# Altium schematic sheet hellen1-mega-mcu176.SchDoc(hellen1-mega-mcu176)
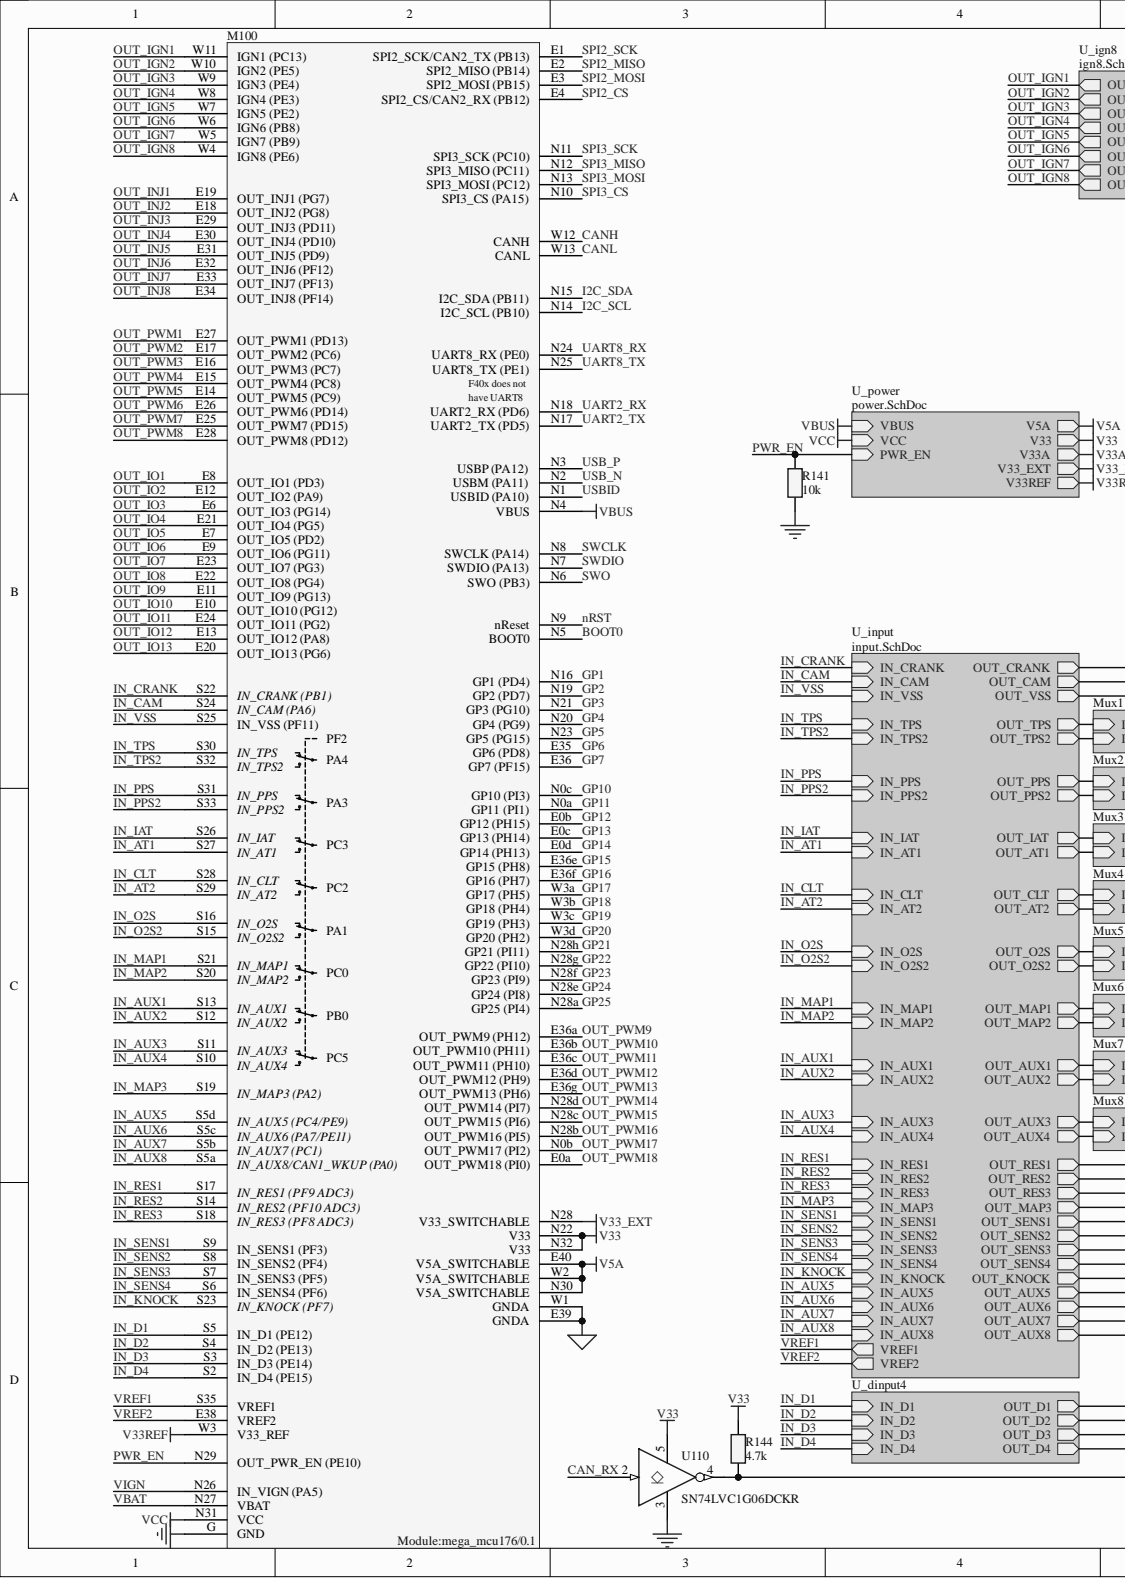
[diagram p0: hellen1-mega-mcu176.SchDoc, left]
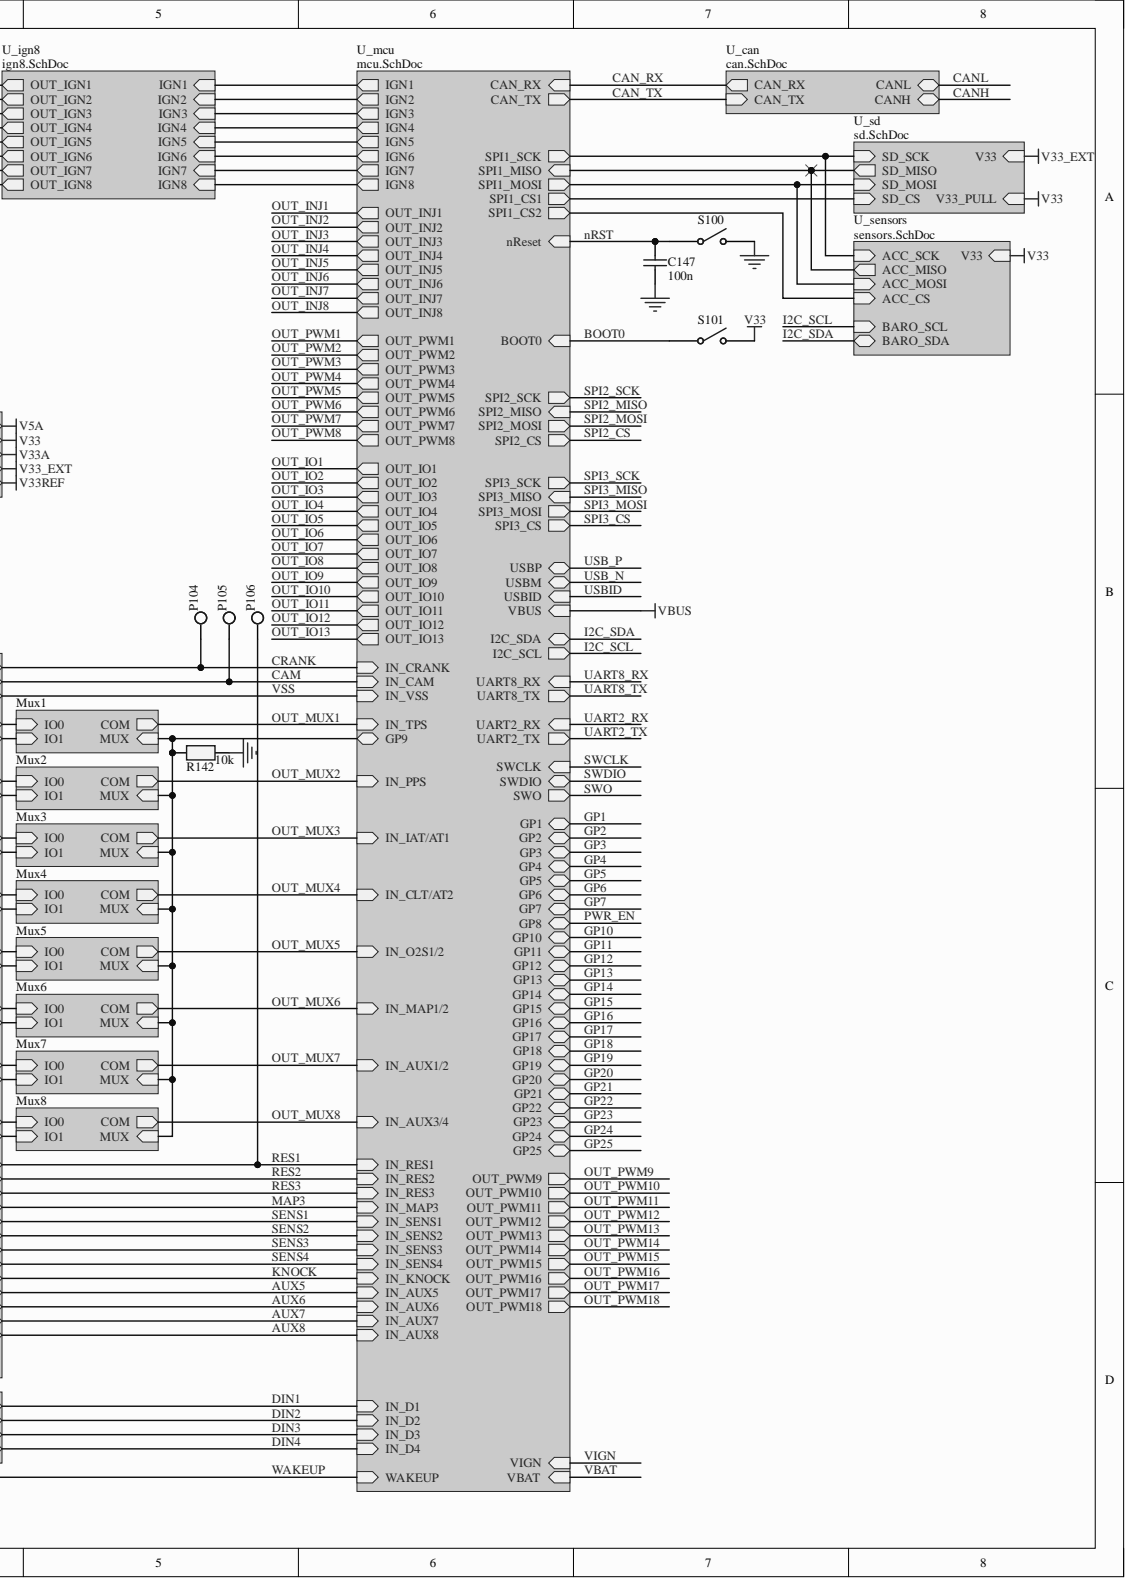
[diagram p1: hellen1-mega-mcu176.SchDoc, right]

## Parts
C147  100n  [C0402HD]
M100  Module:mega_mcu176/0.1  [MOD_Hellen_MEGA_MCU176_0.1]
P104  CRK  [HDR1X1]  (value not drawn)  (no BOM)
P105  CAM  [HDR1X1]  (value not drawn)  (no BOM)
P106  RES1  [HDR1X1]  (value not drawn)  (no BOM)
R141  10k  [R0402]
R142  10k  [R0402]
R144  4.7k  [R0402]
S100  BTN  [BUTTON-SKRK]  (value not drawn)
S101  BTN  [BUTTON-SKRK]  (value not drawn)
U110  SN74LVC1G06DCKR  [SOT-353]

## Nets
GND: C147.1 M100.G(GND) R141.1 R142.2 S100.2 U110.3
GNDA: M100.E39(GNDA) M100.W1(GNDA)
V5A: M100.E40(V5A_SWITCHABLE) M100.N30(V5A_SWITCHABLE) M100.W2(V5A_SWITCHABLE)
V33: M100.N22(V33) M100.N32(V33) R144.1 S101.2 U110.5
V33_EXT: M100.N28(V33_SWITCHABLE)
V33REF: M100.W3(V33_REF)
VBUS: M100.N4(VBUS)
VCC: M100.N31(VCC)
BOOT0: M100.N5(BOOT0) S101.1
CAM: P105.1
CAN_RX: U110.2
CANH: M100.W12(CANH)
CANL: M100.W13(CANL)
CRANK: P104.1
GP1: M100.N16(GP1_(PD4))
GP2: M100.N19(GP2_(PD7))
GP3: M100.N21(GP3_(PG10))
GP4: M100.N20(GP4_(PG9))
GP5: M100.N23(GP5_(PG15))
GP6: M100.E35(GP6_(PD8))
GP7: M100.E36(GP7_(PF15))
GP10: M100.N0c(GP10_(PI3))
GP11: M100.N0a(GP11_(PI1))
GP12: M100.E0b(GP12_(PH15))
GP13: M100.E0c(GP13_(PH14))
GP14: M100.E0d(GP14_(PH13))
GP15: M100.E36e(GP15_(PH8))
GP16: M100.E36f(GP16_(PH7))
GP17: M100.W3a(GP17_(PH5))
GP18: M100.W3b(GP18_(PH4))
GP19: M100.W3c(GP19_(PH3))
GP20: M100.W3d(GP20_(PH2))
GP21: M100.N28h(GP21_(PI11))
GP22: M100.N28g(GP22_(PI10))
GP23: M100.N28f(GP23_(PI9))
GP24: M100.N28e(GP24_(PI8))
GP25: M100.N28a(GP25_(PI4))
I2C_SCL: M100.N14(I2C_SCL_(PB10))
I2C_SDA: M100.N15(I2C_SDA_(PB11))
IN_AT1: M100.S27(IN_AT1)
IN_AT2: M100.S29(IN_AT2)
IN_AUX1: M100.S13(IN_AUX1)
IN_AUX2: M100.S12(IN_AUX2)
IN_AUX3: M100.S11(IN_AUX3)
IN_AUX4: M100.S10(IN_AUX4)
IN_AUX5: M100.S5d(IN_AUX5_(PC4/PE9))
IN_AUX6: M100.S5c(IN_AUX6_(PA7/PE11))
IN_AUX7: M100.S5b(IN_AUX7_(PC1))
IN_AUX8: M100.S5a
IN_CAM: M100.S24(IN_CAM_(PA6))
IN_CLT: M100.S28(IN_CLT)
IN_CRANK: M100.S22(IN_CRANK_(PB1))
IN_D1: M100.S5(IN_D1_(PE12))
IN_D2: M100.S4(IN_D2_(PE13))
IN_D3: M100.S3(IN_D3_(PE14))
IN_D4: M100.S2(IN_D4_(PE15))
IN_IAT: M100.S26(IN_IAT)
IN_KNOCK: M100.S23(IN_KNOCK_(PF7))
IN_MAP1: M100.S21(IN_MAP1)
IN_MAP2: M100.S20(IN_MAP2)
IN_MAP3: M100.S19(IN_MAP3_(PA2))
IN_O2S: M100.S16(IN_O2S)
IN_O2S2: M100.S15(IN_O2S2)
IN_PPS: M100.S31(IN_PPS)
IN_PPS2: M100.S33(IN_PPS2)
IN_RES1: M100.S17(IN_RES1_(PF9_ADC3))
IN_RES2: M100.S14(IN_RES2_(PF10_ADC3))
IN_RES3: M100.S18(IN_RES3_(PF8_ADC3))
IN_SENS1: M100.S9(IN_SENS1_(PF3))
IN_SENS2: M100.S8(IN_SENS2_(PF4))
IN_SENS3: M100.S7(IN_SENS3_(PF5))
IN_SENS4: M100.S6(IN_SENS4_(PF6))
IN_TPS: M100.S30(IN_TPS)
IN_TPS2: M100.S32(IN_TPS2)
IN_VSS: M100.S25(IN_VSS_(PF11))
nRST: C147.2 M100.N9(nReset) S100.1
OUT_IGN1: M100.W11(IGN1_(PC13))
OUT_IGN2: M100.W10(IGN2_(PE5))
OUT_IGN3: M100.W9(IGN3_(PE4))
OUT_IGN4: M100.W8(IGN4_(PE3))
OUT_IGN5: M100.W7(IGN5_(PE2))
OUT_IGN6: M100.W6(IGN6_(PB8))
OUT_IGN7: M100.W5(IGN7_(PB9))
OUT_IGN8: M100.W4(IGN8_(PE6))
OUT_INJ1: M100.E19(OUT_INJ1_(PG7))
OUT_INJ2: M100.E18(OUT_INJ2_(PG8))
OUT_INJ3: M100.E29(OUT_INJ3_(PD11))
OUT_INJ4: M100.E30(OUT_INJ4_(PD10))
OUT_INJ5: M100.E31(OUT_INJ5_(PD9))
OUT_INJ6: M100.E32(OUT_INJ6_(PF12))
OUT_INJ7: M100.E33(OUT_INJ7_(PF13))
OUT_INJ8: M100.E34(OUT_INJ8_(PF14))
OUT_IO1: M100.E8(OUT_IO1_(PD3))
OUT_IO2: M100.E12(OUT_IO2_(PA9))
OUT_IO3: M100.E6(OUT_IO3_(PG14))
OUT_IO4: M100.E21(OUT_IO4_(PG5))
OUT_IO5: M100.E7(OUT_IO5_(PD2))
OUT_IO6: M100.E9(OUT_IO6_(PG11))
OUT_IO7: M100.E23(OUT_IO7_(PG3))
OUT_IO8: M100.E22(OUT_IO8_(PG4))
OUT_IO9: M100.E11(OUT_IO9_(PG13))
OUT_IO10: M100.E10(OUT_IO10_(PG12))
OUT_IO11: M100.E24(OUT_IO11_(PG2))
OUT_IO12: M100.E13(OUT_IO12_(PA8))
OUT_IO13: M100.E20(OUT_IO13_(PG6))
OUT_PWM1: M100.E27(OUT_PWM1_(PD13))
OUT_PWM2: M100.E17(OUT_PWM2_(PC6))
OUT_PWM3: M100.E16(OUT_PWM3_(PC7))
OUT_PWM4: M100.E15(OUT_PWM4_(PC8))
OUT_PWM5: M100.E14(OUT_PWM5_(PC9))
OUT_PWM6: M100.E26(OUT_PWM6_(PD14))
OUT_PWM7: M100.E25(OUT_PWM7_(PD15))
OUT_PWM8: M100.E28(OUT_PWM8_(PD12))
OUT_PWM9: M100.E36a(OUT_PWM9_(PH12))
OUT_PWM10: M100.E36b(OUT_PWM10_(PH11))
OUT_PWM11: M100.E36c(OUT_PWM11_(PH10))
OUT_PWM12: M100.E36d(OUT_PWM12_(PH9))
OUT_PWM13: M100.E36g(OUT_PWM13_(PH6))
OUT_PWM14: M100.N28d(OUT_PWM14_(PI7))
OUT_PWM15: M100.N28c(OUT_PWM15_(PI6))
OUT_PWM16: M100.N28b(OUT_PWM16_(PI5))
OUT_PWM17: M100.N0b(OUT_PWM17_(PI2))
OUT_PWM18: M100.E0a(OUT_PWM18_(PI0))
PWR_EN: M100.N29(OUT_PWR_EN_(PE10)) R141.2
RES1: P106.1
SPI2_CS: M100.E4(SPI2_CS/CAN2_RX_(PB12))
SPI2_MISO: M100.E2(SPI2_MISO_(PB14))
SPI2_MOSI: M100.E3(SPI2_MOSI_(PB15))
SPI2_SCK: M100.E1(SPI2_SCK/CAN2_TX_(PB13))
SPI3_CS: M100.N10(SPI3_CS_(PA15))
SPI3_MISO: M100.N12(SPI3_MISO_(PC11))
SPI3_MOSI: M100.N13(SPI3_MOSI_(PC12))
SPI3_SCK: M100.N11(SPI3_SCK_(PC10))
SWCLK: M100.N8(SWCLK_(PA14))
SWDIO: M100.N7(SWDIO_(PA13))
SWO: M100.N6(SWO_(PB3))
UART2_RX: M100.N18(UART2_RX_(PD6))
UART2_TX: M100.N17(UART2_TX_(PD5))
UART8_RX: M100.N24(UART8_RX_(PE0))
UART8_TX: M100.N25(UART8_TX_(PE1))
USB_N: M100.N2(USBM_(PA11))
USB_P: M100.N3(USBP_(PA12))
USBID: M100.N1(USBID_(PA10))
VBAT: M100.N27(VBAT)
VIGN: M100.N26(IN_VIGN_(PA5))
VREF1: M100.S35(VREF1)
VREF2: M100.E38(VREF2)
WAKEUP: R144.2 U110.4
NetR142_1: R142.1
ACC_CS:
ACC_MISO:
ACC_MOSI:
ACC_SCK:
AUX5:
AUX6:
AUX7:
AUX8:
CAN_TX:
DIN1:
DIN2:
DIN3:
DIN4:
IGN1:
IGN2:
IGN3:
IGN4:
IGN5:
IGN6:
IGN7:
IGN8:
IO0:
IO1:
KNOCK:
MAP3:
OUT_MUX1:
OUT_MUX2:
OUT_MUX3:
OUT_MUX4:
OUT_MUX5:
OUT_MUX6:
OUT_MUX7:
OUT_MUX8:
RES2:
RES3:
SD_CS:
SENS1:
SENS2:
SENS3:
SENS4:
V33A:
VSS:

## Library data of the parts
C147 = Cap: Capacitor; Fitted: True; Type: SMD; Component Kind: Standard; Library Name: General.schlib; PackageReference: C0402; Signal Integrity: Capacitor; Supplier 3: LCSC; Supplier Part Number 3: C1525
M100 = Mod-Hellen-MEGA_MCU176: Hellen One MEGA_MCU176 Module; Fitted: False; Component Kind: Standard; Library Name: Mod.SchLib; Supplier 1: Mouser; Supplier 2: Digi-Key; Supplier 3: LCSC; Type: Module; Publisher: qwerty-off
P104-P106 = Pad: Fitted: False; Description: Pad; Library Name: General.schlib
R141 R142 R144 = Res: Resistor; Fitted: True; Component Kind: Standard; Library Name: General.schlib; PackageReference: R0402; Signal Integrity: Resistor; Supplier 3: LCSC; Type: SMD
  R141: PCB3D: 0402; Supplier Part Number 3: C25744
  R142: PCB3D: 0805; Supplier Part Number 3: C25744
  R144: PCB3D: 0402; Supplier 1: Mouser; Supplier 2: DigiKey; Supplier Part Number 3: C25900
S100 S101 = Switch SPST: Single-Pole, Single-Throw Switch; Fitted: True; Component Kind: Standard; LatestRevisionDate: 17-Jul-2002; LatestRevisionNote: Re-released for DXP Platform.; Library Name: General.schlib; PackageReference: SMD-2_2.9x3.9x1.7; Published: 24-Mar-1999; Supplier 3: LCSC; Supplier Part Number 3: C115357; Type: SMD; Publisher: Altium Limited
U110 = 74LVC1G06: Component Kind: Standard; Library Name: IC.SchLib; PackageReference: SOT-353; Supplier 1: Mouser; Supplier 2: DigiKey; Supplier 3: LCSC; Supplier Part Number 3: C7828; Type: SMD
